# Altium schematic sheet Signal_Conditioning.SchDoc(Signal_Conditioning1)
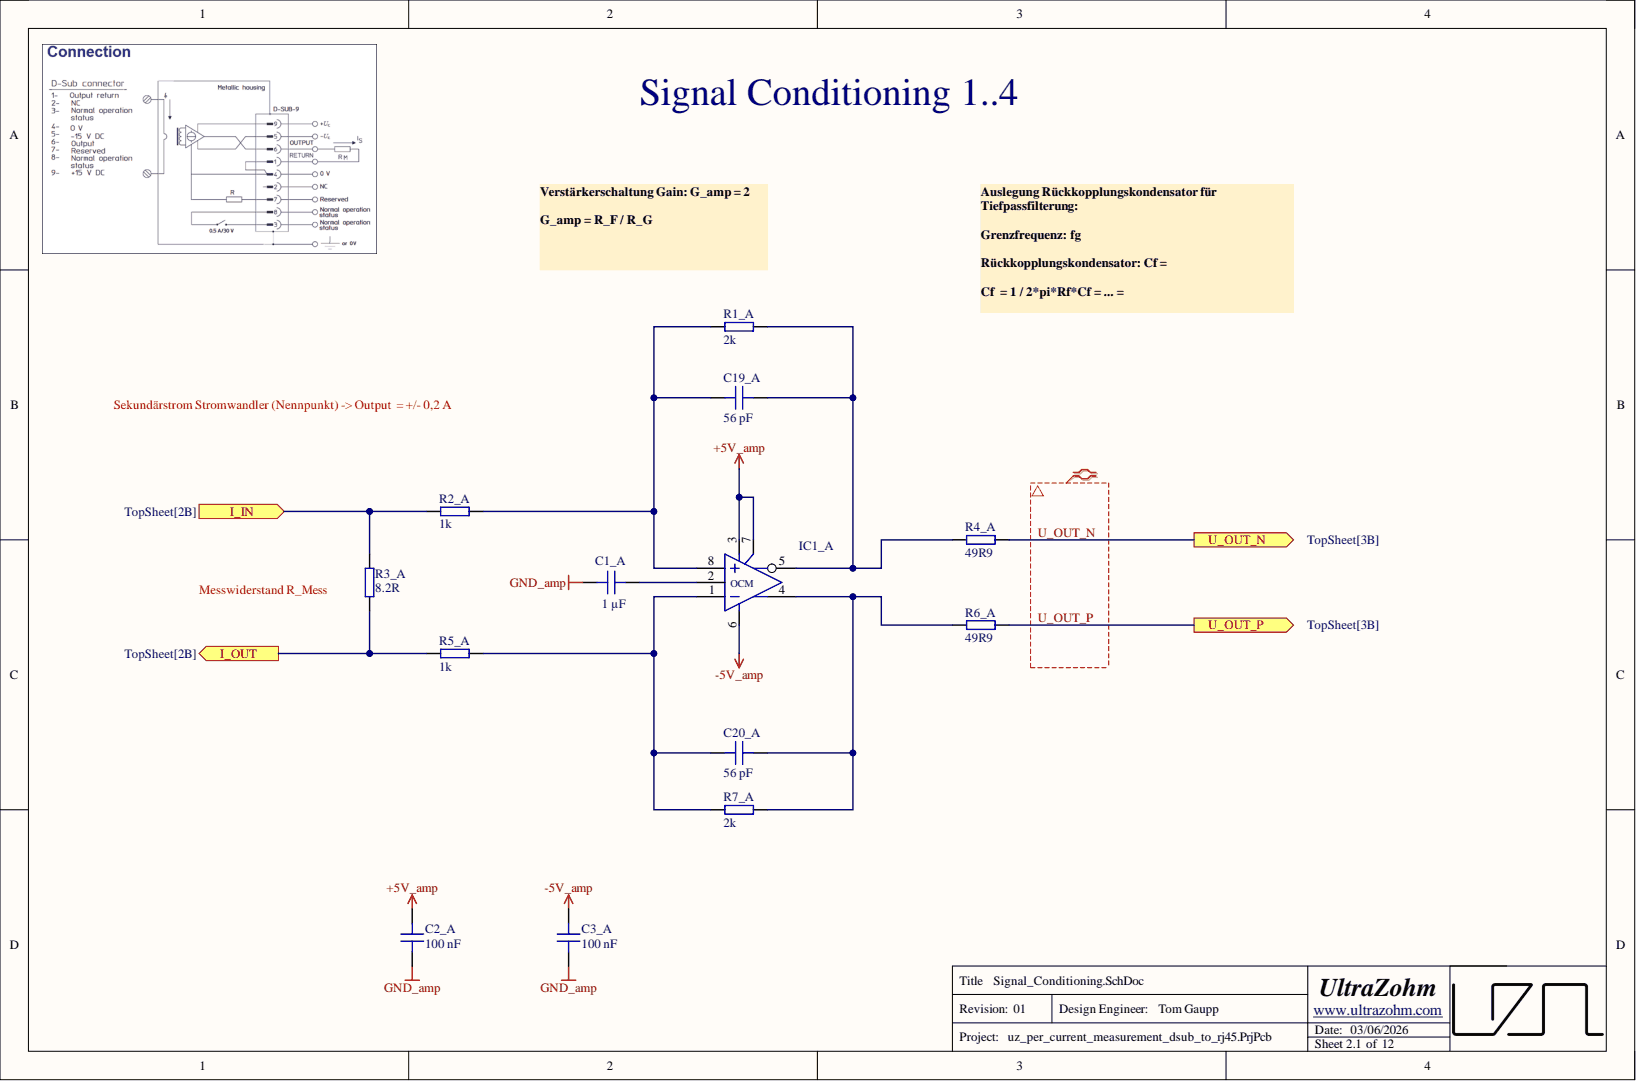
[diagram p0: Signal_Conditioning.SchDoc]

## Parts
C1_A  1 µF  [C_0603]
C2_A  100 nF  [C_0603]
C3_A  100 nF  [C_0603]
C19_A  56 pF  [C_0603]
C20_A  56 pF  [C_0603]
IC1_A  ADA4940-1ARZ-R7  [SOIC-8-N]  (value not drawn)
R1_A  2k  [RES 1206_3216]
R2_A  1k  [RES 1206_3216]
R3_A  8.2R  [RES 2010_5025]
R4_A  49R9  [RES 0603_1608]
R5_A  1k  [RES 1206_3216]
R6_A  49R9  [RES 0603_1608]
R7_A  2k  [RES 1206_3216]

## Ports
I_IN_A, I_OUT_A, U_OUT_A_N, U_OUT_A_P

## Nets
+5V_amp: C2_A.2 IC1_A.3 IC1_A.7
-5V_amp: C3_A.2 IC1_A.6
GND_amp: C1_A.1 C2_A.1 C3_A.1
U_OUT_A_N: R4_A.2  [also labelled A_OUT_N]
U_OUT_A_P: R6_A.2  [also labelled A_OUT_P]
NetC1_A_2: C1_A.2 IC1_A.2(OCM)
NetC19_A_1: C19_A.1 IC1_A.8 R1_A.1 R2_A.2
NetC19_A_2: C19_A.2 IC1_A.5 R1_A.2 R4_A.1
NetC20_A_1: C20_A.1 IC1_A.1 R5_A.2 R7_A.1
NetC20_A_2: C20_A.2 IC1_A.4 R6_A.1 R7_A.2
NetR2_A_1: R2_A.1 R3_A.2
NetR3_A_1: R3_A.1 R5_A.1

## Library data of the parts
C1_A = CL10A105KB8NNNC: 50V 1uF X5R ±10% 0603  Multilayer Ceramic Capacitors MLCC - SMD/SMT ROHS; Manufacturer: Samsung Electro-Mechanics; Created from: MGremer; Database Table Name: Capacitors - SMD; Voltage Rating: 50 VDC; Component Kind: Standard; Component Type: Capacitor 0603; ComponentLink1Description: Datasheet; ComponentLink1URL: https://datasheet.lcsc.com/lcsc/1810261812_Samsung-Electro-Mechanics-CL10A105KB8NNNC_C15849.pdf; Created On: 20/12/2020 13:54:13; Device Package: 0603; Last Updated: 28/11/2022 17:23:01; LCSC Part Number: C15849; Library Name: user.DbLib; Live Cycle Status: 1; Manufacturer Part Number: CL10A105KB8NNNC; Mounting Type: SMD; Operating Temperature: -55 - 85°C; PartID: 5; Series: M; Stock number: Labor SMD Container; Supplier 1: LCSC; Supplier Part Number 1: C15849; Tolerance: 10 %; Type: Capacitor; Value: 1 µF
C2_A C3_A = C0603C104K5RAC3121: Capacitor 0603, 50 VDC, 100nF, X7R; Manufacturer: KEMET; Created from: DHufnagel; Database Table Name: Capacitors - SMD; Tolerance: 10 %; Voltage Rating: 50 VDC; Component Kind: Standard; Component Type: Capacitor 0603; ComponentLink1Description: Datasheet; ComponentLink1URL: https://www.mouser.de/datasheet/2/212/KEM_C1023_X7R_AUTO_SMD-1093309.pdf; Created On: 11/08/2022 14:33:29; Device Package: 0603; Last Updated: 19/08/2022 08:26:58; LCSC Part Number: C696358; Library Name: user.DbLib; Live Cycle Status: 1; Manufacturer Part Number: C0603C104K5RAC3121; Mounting Type: SMD; Operating Temperature: -55 - 125°C; PartID: 99; Series: X7R; Stock number: not stored; Supplier 1: Mouser; Supplier 2: LCSC; Supplier Part Number 1: 80-C603C104K5RAC3121; Supplier Part Number 2: C696358; Type: Capacitor; Value: 100 nF
C19_A C20_A = CL10C560JB8NNNC: Capacitor 0603, 50 VDC, 56 pF, C0G; Manufacturer: Samsung Electro-Mechanics; Created from: DHufnagel; Database Table Name: Capacitors - SMD; Tolerance: 5 %; Voltage Rating: 50 VDC; Component Kind: Standard; Component Type: Capacitor 0603; ComponentLink1Description: Datasheet; ComponentLink1URL: https://www.mouser.de/datasheet/2/585/MLCC-1837944.pdf; Created On: 20/12/2020 13:54:13; Device Package: 0603; Last Updated: 20/12/2020 16:24:16; LCSC Part Number: C39148; Library Name: user.DbLib; Live Cycle Status: 1; Manufacturer Part Number: CL10C560JB8NNNC; Mounting Type: SMD; Operating Temperature: -55 - 125°C; PartID: 92; Series: CL; Stock number: not stored; Supplier 1: Mouser; Supplier 2: LCSC; Supplier Part Number 1: 187-CL10C560JB8NNNC; Supplier Part Number 2: C39148; Type: Capacitor; Value: 56 pF
IC1_A = ADA4940-1ARZ-R7: Differential ADC Driver; Manufacturer: Analog Devices; Best.-Nr.: Farnell 209-6178; Channels: 1; Class I: Analog; Class II: Fully Differential ADC Drivers (-40-125°C); Created from: MGremer; Component Kind: Standard; Component Type: ADC; ComponentLink1Description: Datasheet; ComponentLink1URL: https://www.mouser.de/datasheet/2/609/ADA4940-1_4940-2-878284.pdf; Created On: 15/01/2021 12:16:53; Database Table Name: ADC; Datasheet: DSO11449, Ver. Aug 2000; Device Package: SOIC-8; Last edited: 04.01.2007 10:14; Last Updated: 17/02/2026 13:51:19; LCSC Part Number: C730049; Library Name: user.DbLib; Live Cycle Status: 1; Manufacturer Part Number: ADA4940-1ARZ-R7; Mounting Type: SMD; Operating Temperature: -40 - 105 °C; Package Reference: SOIC-N-8; PartID: 3; Resolution: -; Stock number: not stored; Supplier 1: Mouser; Supplier 2: LCSC; Supplier Part Number 1: 584-ADA4940-1ARZ-R7; Supplier Part Number 2: C730049; Type: ADC; Value: differential ADC driver
R1_A R7_A = ERA-8AEB202V: 2kΩ ±0.1% 250mW 1206; Manufacturer: Panasonic; Created from: TGaupp; Database Table Name: Resistors - SMD; Component Kind: Standard; Component Type: SMD resistor; ComponentLink1Description: Datasheet; ComponentLink1URL: https://www.lcsc.com/datasheet/C2073471.pdf; Created On: 17/02/2026 13:38:22; Device Package: 1206; Last Updated: 17/02/2026 13:39:41; LCSC Part Number: C2073471; Library Name: user.DbLib; Live Cycle Status: 1; Manufacturer Part Number: ERA-8AEB202V; Mounting Type: SMD; PartID: 384; Stock number: not stored; Supplier 1: Mouser; Supplier 2: LCSC; Supplier Part Number 1: 667-ERA-8AEB202V; Supplier Part Number 2: C2073471; Tolerance: 0.1%; Type: Resistor; Value: 2k
R2_A R5_A = ERA-8AEB102V: SMD resistor 1/4W 1.0Kohm 0.1% 25ppm; Manufacturer: Panasonic; Created from: TGaupp; Database Table Name: Resistors - SMD; Component Kind: Standard; Component Type: SMD resistor; ComponentLink1Description: Datasheet; ComponentLink1URL: https://www.mouser.de/datasheet/2/315/AOA0000C307-1149632.pdf; Created On: 08/08/2023 13:03:51; Device Package: 1206; Last Updated: 17/02/2026 13:14:39; LCSC Part Number: C491153; Library Name: user.DbLib; Live Cycle Status: 1; Manufacturer Part Number: ERA-8AEB102V; Mounting Type: SMD; PartID: 292; Stock number: not stored; Supplier 1: Mouser; Supplier Part Number 1: 667-ERA-8AEB102V; Tolerance: 0.1%; Type: Resistor; Value: 1k
R3_A = RC2010FK-078R2L: -55℃~+155℃ 200V 750mW 8.2Ω Thick Film Resistor ±1% ±200ppm/℃ 2010 Chip Resistor; Manufacturer: YAEGO; Created from: TGaupp; Database Table Name: Resistors - SMD; Component Kind: Standard; Component Type: SMD resistor; ComponentLink1Description: Datasheet; ComponentLink1URL: https://www.lcsc.com/datasheet/C877647.pdf?spm=wm.sxq.inf.ggs___wm.fly.bg.1.xh&lcsc_vid=QQAPVAECQgdWVlZeR1YKVFcAFllaVVcHTlRZAgUCQFkxVlNeR1VZUlNUTlJbUDsOAxUeFF5JWBYZEEoKFBINSQcJGk4eFQsCAgIaSgADAwAHC0slTldYV1ZfWQkaCgg%3D; Created On: 03/06/2026 12:29:37; Device Package: 2010; Last Updated: 03/06/2026 13:37:55; LCSC Part Number: C877647; Library Name: user.DbLib; Live Cycle Status: 1; Manufacturer Part Number: RC2010FK-078R2L; Mounting Type: SMD; PartID: 393; Supplier 1: LCSC; Supplier Part Number 1: C877647; Tolerance: 1%; Type: Resistor; Value: 8.2R
R4_A R6_A = RC0603FR-0749R9L: 100mW Thick Film Resistors ±100ppm/℃ ±1% 49.9Ω 0603 Chip Resistor - Surface Mount ROHS; Manufacturer: YAGEO; Created from: AGeiger; Database Table Name: Resistors - SMD; Component Kind: Standard; Component Type: SMD resistor; ComponentLink1Description: Datasheet; ComponentLink1URL: https://datasheet.lcsc.com/lcsc/2304140030_YAGEO-RC0603FR-0749R9L_C114625.pdf; Created On: 14.06.2023 17:42:26; Device Package: 0603; Last Updated: 14.06.2023 17:42:26; LCSC Part Number: C114625; Library Name: user.DbLib; Live Cycle Status: 1; Manufacturer Part Number: RC0603FR-0749R9L; Mounting Type: SMD; PartID: 277; Supplier 1: Mouser; Supplier Part Number 1: 603-RC0402FR-0749R9L; Tolerance: 1%; Type: Resistor; Value: 49R9
